# Altium schematic sheet switch_peripherials.SchDoc
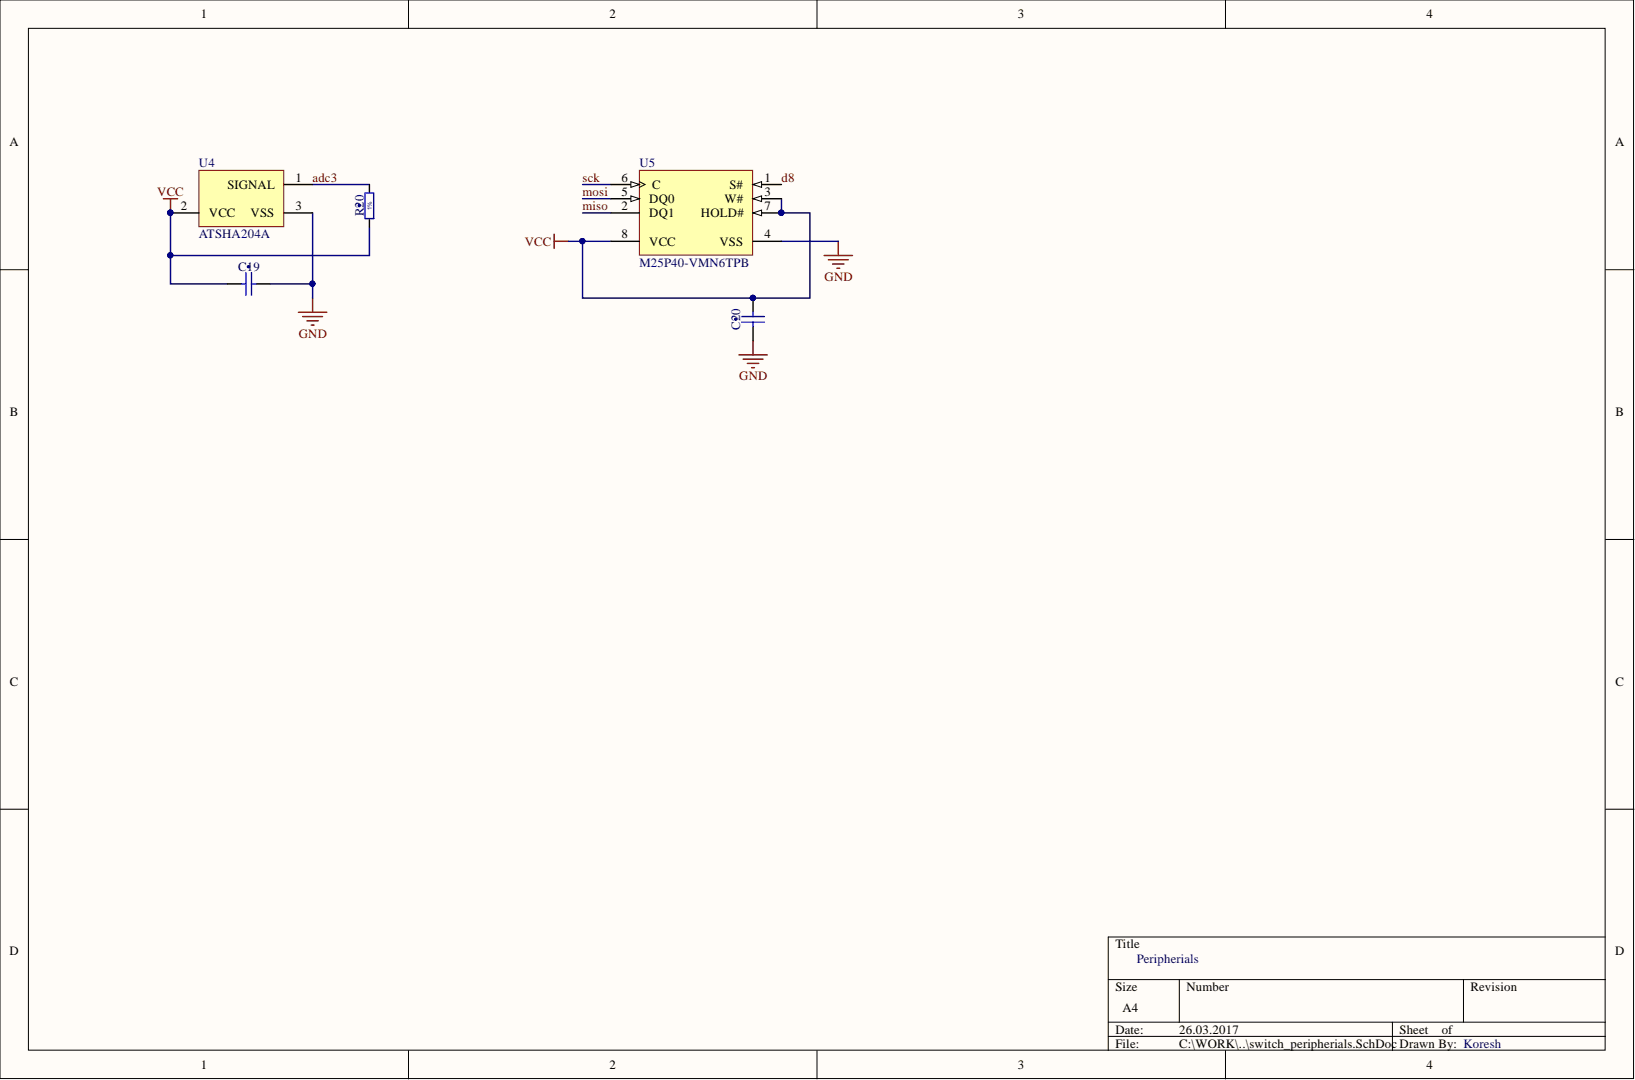
[diagram p0: switch_peripherials.SchDoc]

## Parts
C19  100nF  [CAPC1608X90N]  (value not drawn)
C20  100nF  [CAPC1608X90N]  (value not drawn)
R20  100k  [RESC1608X55N]  (value not drawn)
U4  ATSHA204A  [3TS1_N]
U5  M25P40-VMN6TPB  [MICT-MN-8_N]

## Nets
GND: C19.2 C20.1 U4.3 U5.4(VSS)
VCC: C19.1 C20.2 R20.1 U4.2 U5.3(W#) U5.7(HOLD#) U5.8(VCC)
ADC3: R20.2 U4.1
D8: U5.1(S#)
MISO: U5.2(DQ1)
MOSI: U5.5(DQ0)
SCK: U5.6(C)

## Library data of the parts
C19 C20 = CC0603_100NF_100V_10%_X7R: Non-polarised capacitor; Manufacturer: GENERIC; Manufacturer1 Part Number: GRM188R72A104KA35D; Part Description: SMD Multilayer Chip Ceramic Capacitor; Database Table Name: Capacitors SMD; TC: X7R; Tolerance: ±10%; Voltage: 100V; Case: 0603; Component Kind: Standard; Component Type: Standard; CreateDate: 11.12.2013; HelpURL: \\cern.ch\dfs\Applications\Altium\Datasheets\CC0603_MURATA_GRM188R72A104KA35D.pdf; LatestRevisionDate: 11.12.2013; Library Name: Capacitors.DbLib; Manufacturer1 ComponentHeight: 0.9mm; Manufacturer1 Example: MURATA; Manufacturer Part Number: CC0603_100NF_100V_10%_X7R; Mounted: Yes; Part Number: CC0603_100NF_100V_10%_X7R; Pin Count: 2; SMD: Yes; Socket: No; Status: None; Table: Capacitors SMD; Value: 100nF; Author: CERN DEM JLC
R20 = R0603_4K7_1%_0.1W_100PPM: Resistor - 1%; Manufacturer: GENERIC; Manufacturer1 Part Number: NRC06F4701TRF; Part Description: General Purpose Thick Film Chip Resistor; Database Table Name: Resistors SMD; Power: 0.1W; TC: ±100ppm/°C; Case: 0603; Component Kind: Standard; Component Type: Standard; CreateDate: 03.12.2007; HelpURL: \\cern.ch\dfs\Applications\Altium\Datasheets\R0603_NIC_NRC.pdf; LatestRevisionDate: 13.03.2008; Library Name: Resistors.DbLib; Manufacturer1 ComponentHeight: 0.55mm; Manufacturer1 Example: NIC COMPONENT; Manufacturer Part Number: R0603_4K7_1%_0.1W_100PPM; Mounted: Yes; Part Number: R0603_4K7_1%_0.1W_100PPM; Pin Count: 2; SMD: Yes; Socket: No; Status: Preferred; Table: Resistors SMD; Tolerance: ±1%; Value: 100k; Author: CERN DEM JLC
U4 = AT88SA10HS-TSU-T: CryptoAuthentication™ Host Security Chip, 3-Pin SOT; Code_IPC: SOT23-3; Code_JEDEC: TO-236-AB; Component Kind: Standard; ComponentLink1Description: Manufacturer URL; ComponentLink1URL: http://www.atmel.com/; ComponentLink2Description: Datasheet; ComponentLink2URL: http://www.atmel.com/dyn/resources/prod_documents/doc8595.pdf; DatasheetVersion: revD, May-2010; Library Name: Atmel Security Smart Card.IntLib; PackageDescription: 3-Pin Plastic Thin Shrink Small Outline Package, 2.9 x 1.3 mm Body, 0.95 mm Pitch (Shrink SOT); PackageReference: 3TS1; PackageVersion: revA,Nov-2008
U5 = CMP-0357-00076-1: 4 Mb (x1) Serial NOR Flash Memory, 75 MHz, 2.3 to 3.6 V, 8-pin SO8 Narrow (MN), Tape & Reel; Manufacturer: Micron; Part Family: M25PÂ; Density: 4MbÂ; Speed: 75 MHzÂ; Voltage: 2.3V-3.6VÂ; Applications: EmbeddedÂ; Component Kind: Standard; ComponentLink1Description: Manufacturer URL; ComponentLink1URL: http://www.micron.com/; ComponentLink2Description: Datasheet; ComponentLink2URL: http://www.micron.com/~/media/Documents/Products/Data%20Sheet/NOR%20Flash/Serial%20NOR/M25P/M25P40.pdf; ComponentLink3Description: Package Specification; ComponentLink3URL: http://www.micron.com/~/media/Documents/Products/Data%20Sheet/NOR%20Flash/Serial%20NOR/M25P/M25P05A.pdf; DatasheetVersion: Rev. Y; Library Name: Altium Content Vault; Mounting Technology: Surface Mount; Package: SO8 NarrowÂ; PackageDescription: 8-Pin SO Narrow, 3.9 X 4.9 mm, Pitch 1.27 mm; PackageReference: MICT-MN-8; PackageVersion: Rev 11; PartNumber: M25P40-VMN6TPB; Part Status: ProductionÂ; RoHS: YesÂ; Type: Data StorageÂ; Width: x1Â
